# Altium schematic sheet Port.SchDoc
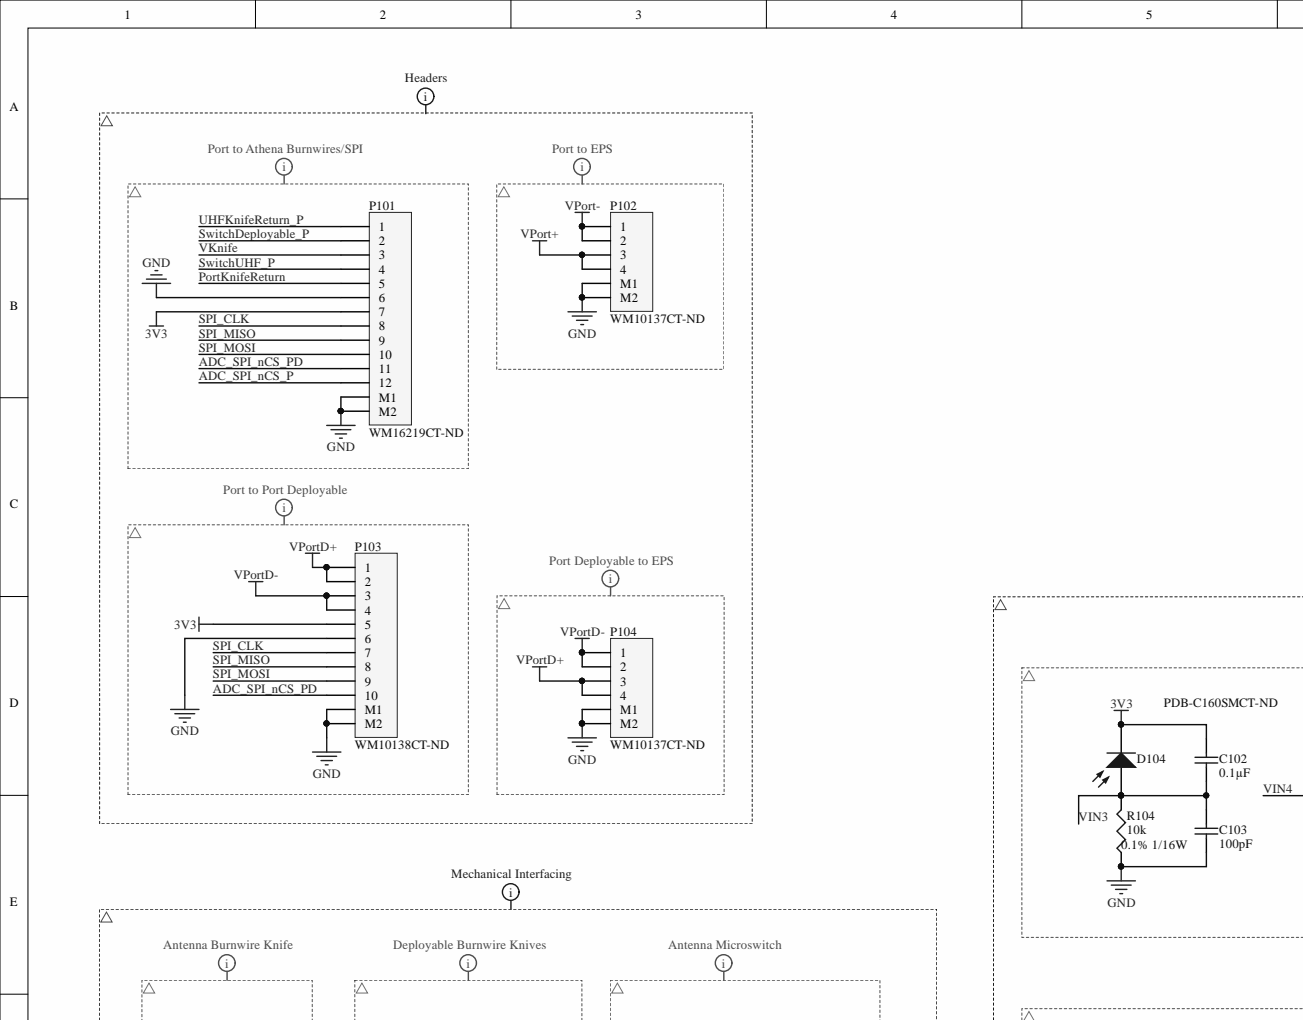
[diagram p0: Port.SchDoc, top left]
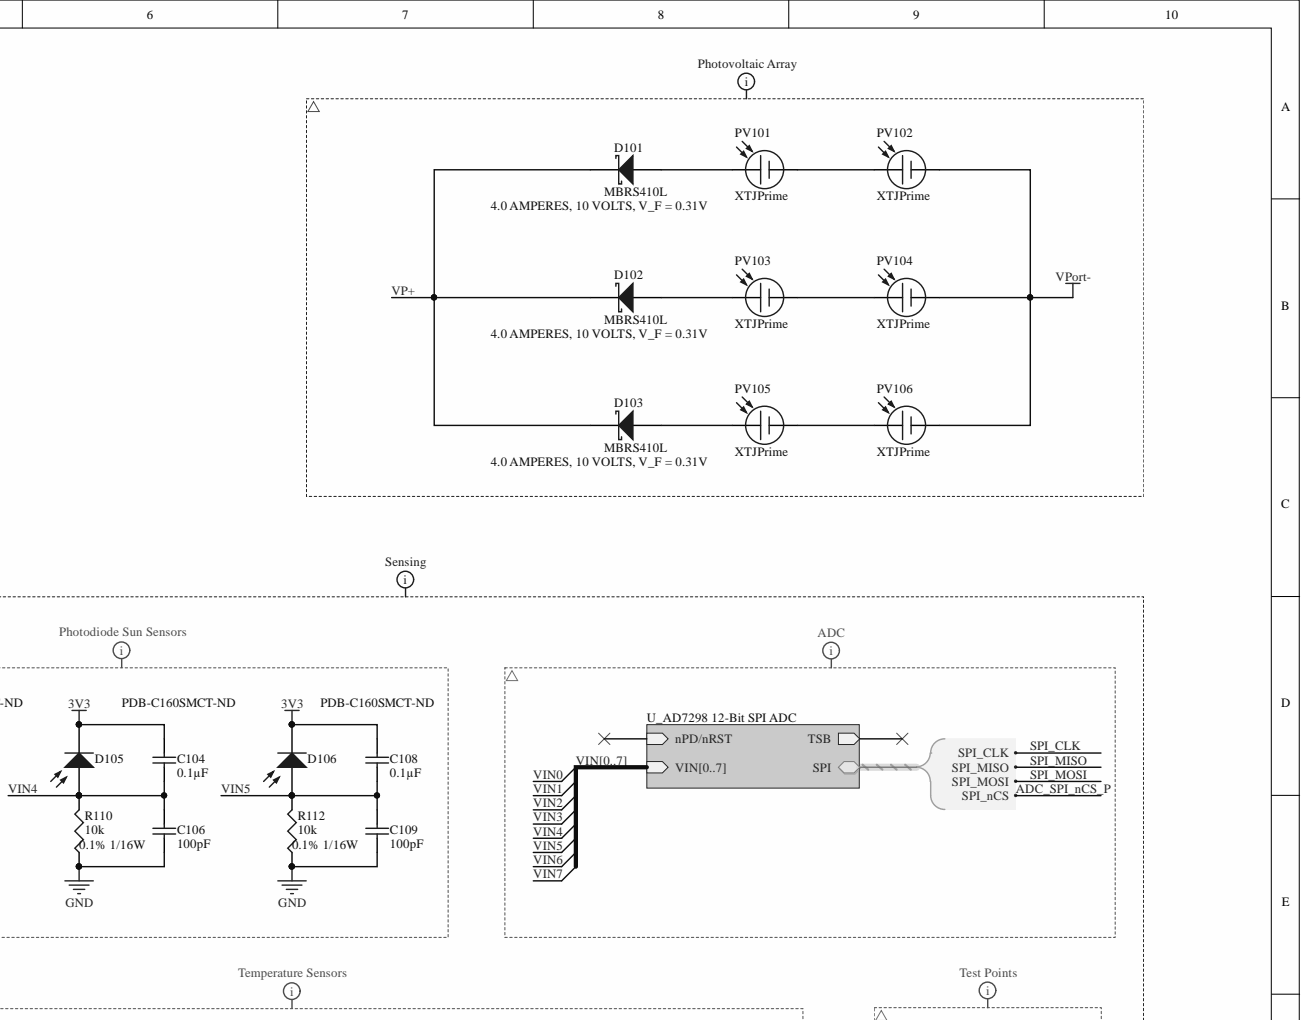
[diagram p1: Port.SchDoc, top right]
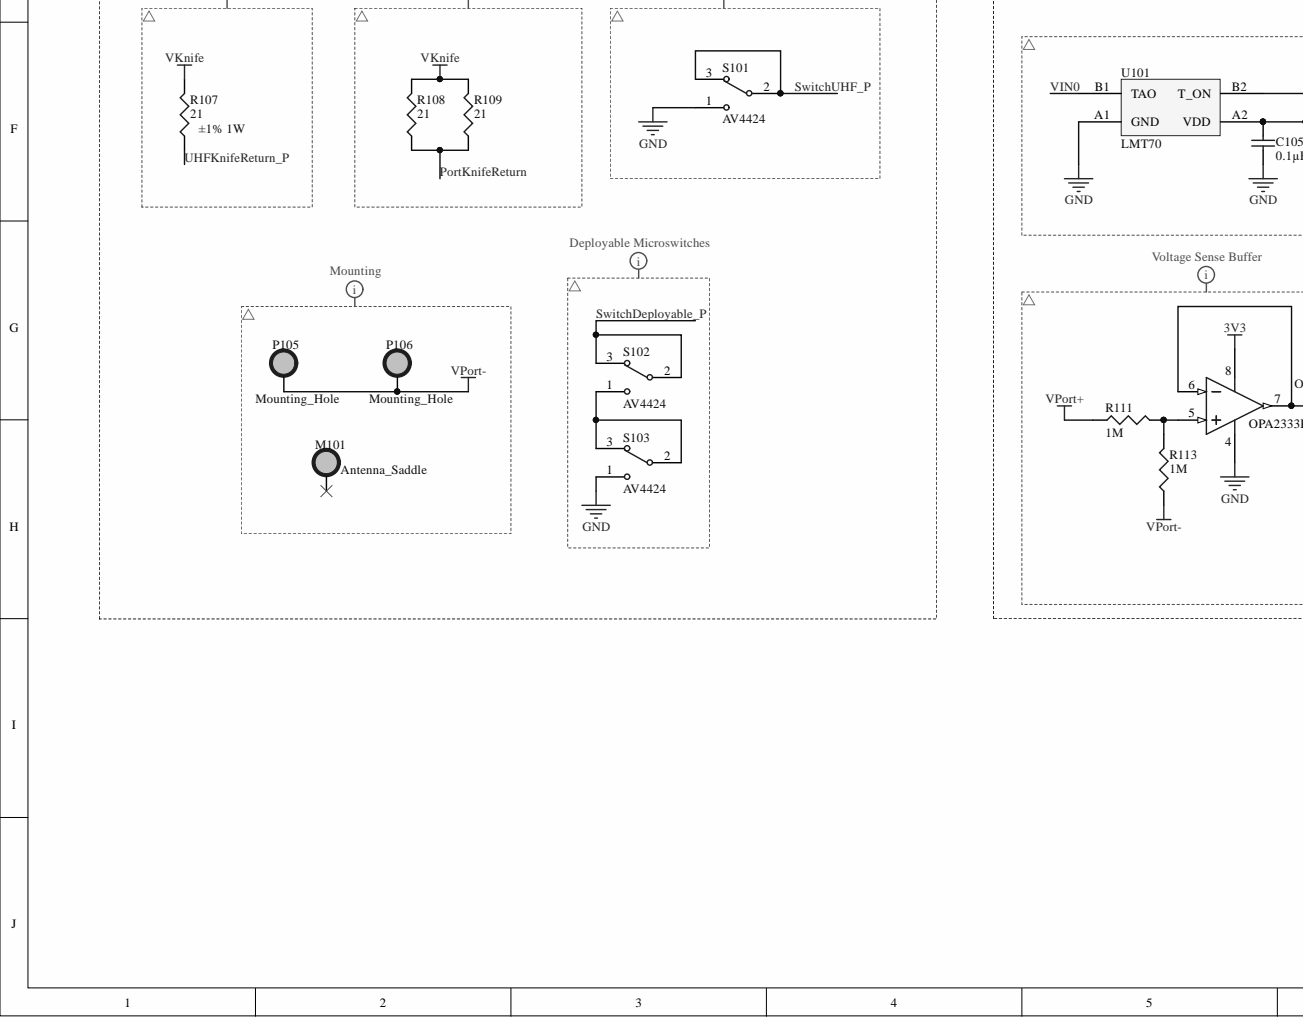
[diagram p2: Port.SchDoc, bottom left]
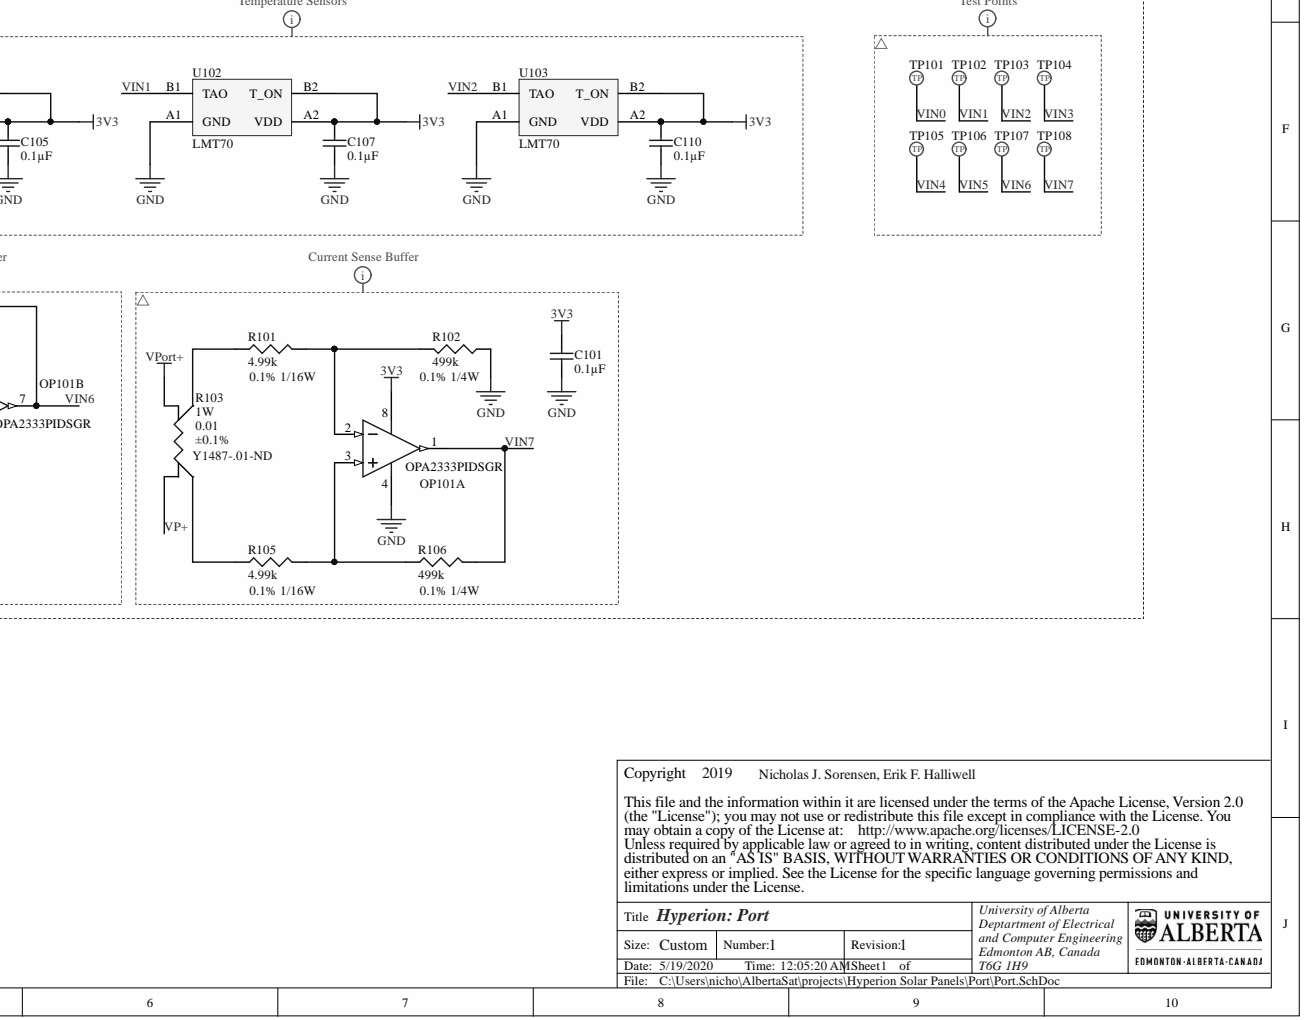
[diagram p3: Port.SchDoc, bottom right]

## Parts
C101  value 0.1µF  [TDK-CGA2B]  (hidden comment: Cap)
C102  value 0.1µF  [TDK-CGA2B]  (hidden comment: Cap)
C103  value 100pF  [TDK-CGA2B]  (hidden comment: Cap)
C104  value 0.1µF  [TDK-CGA2B]  (hidden comment: Cap)
C105  value 0.1µF  [TDK-CGA2B]  (hidden comment: Cap)
C106  value 100pF  [TDK-CGA2B]  (hidden comment: Cap)
C107  value 0.1µF  [TDK-CGA2B]  (hidden comment: Cap)
C108  value 0.1µF  [TDK-CGA2B]  (hidden comment: Cap)
C109  value 100pF  [TDK-CGA2B]  (hidden comment: Cap)
C110  value 0.1µF  [TDK-CGA2B]  (hidden comment: Cap)
D101  MBRS410L  [ONS-875]
D102  MBRS410L  [ONS-875]
D103  MBRS410L  [ONS-875]
D104  PDB-C160SMCT-ND  [PDB-C160SM]
D105  PDB-C160SMCT-ND  [PDB-C160SM]
D106  PDB-C160SMCT-ND  [PDB-C160SM]
M101  Antenna_Saddle  [ANTENNA_SADDLE]
OP101  OPA2333  Manufacturer Part Number: OPA2333PIDSGR  [TI-DSG0008A]  (parts A,B)
P101  WM16219CT-ND  [MOLX-5040501291]
P102  WM10137CT-ND  [MOLX-5040500491]
P103  WM10138CT-ND  [MOLX-5040501091]
P104  WM10137CT-ND  [MOLX-5040500491]
P105  Mounting_Hole  [DEPHINGE_MOUNTING_HOLE_BODY]
P106  Mounting_Hole  [DEPHINGE_MOUNTING_HOLE_BODY]
PV101  XTJPrime  [XTJ Prime]
PV102  XTJPrime  [XTJ Prime]
PV103  XTJPrime  [XTJ Prime]
PV104  XTJPrime  [XTJ Prime]
PV105  XTJPrime  [XTJ Prime]
PV106  XTJPrime  [XTJ Prime]
R101  0.1% 1/16W  value 4.99k  [PANA-ERA2A]
R102  0.1% 1/4W  value 499k  [PANA-ERA8A]
R103  Y1487-.01-ND  value 0.01  Power - Rated: 1W  Tolerance: ±0.1%  [VSH-2512R4C]
R104  0.1% 1/16W  value 10k  [PANA-ERA2A]
R105  0.1% 1/16W  value 4.99k  [PANA-ERA2A]
R106  0.1% 1/4W  value 499k  [PANA-ERA8A]
R107  ±1% 1W  value 21  Power - Rated: 1W  Tolerance: ±1%  [VSH-CPF1]
R108  value 21  [VSH-CPF1]  (hidden comment: Res3)
R109  value 21  [VSH-CPF1]  (hidden comment: Res3)
R110  0.1% 1/16W  value 10k  [PANA-ERA2A]
R111  value 1M  [PANA-ERA6A]  (hidden comment: Res3)
R112  0.1% 1/16W  value 10k  [PANA-ERA2A]
R113  value 1M  [PANA-ERA6A]  (hidden comment: Res3)
S101  AV4424  [PANA-AV4424]
S102  AV4424  [PANA-AV4424]
S103  AV4424  [PANA-AV4424]
TP101  5017  [KS-5017]  (value not drawn)
TP102  5017  [KS-5017]  (value not drawn)
TP103  5017  [KS-5017]  (value not drawn)
TP104  5017  [KS-5017]  (value not drawn)
TP105  5017  [KS-5017]  (value not drawn)
TP106  5017  [KS-5017]  (value not drawn)
TP107  5017  [KS-5017]  (value not drawn)
TP108  5017  [KS-5017]  (value not drawn)
U101  LMT70  [TI-YFQ0004]
U102  LMT70  [TI-YFQ0004]
U103  LMT70  [TI-YFQ0004]

## Nets
3V3: C101.2 C102.2 C104.2 C105.2 C107.2 C108.2 C110.2 D104.2 D105.2 D106.2 OP101.8 P101.7 P103.5 U101.A2(VDD) U101.B2(T_ON) U102.A2(VDD) U102.B2(T_ON) U103.A2(VDD) U103.B2(T_ON)
GND: C101.1 C103.1 C105.1 C106.1 C107.1 C109.1 C110.1 OP101.4 P101.6 P101.M1 P101.M2 P102.M1 P102.M2 P103.6 P103.M1 P103.M2 P104.M1 P104.M2 R102.1 R104.1 R110.1 R112.1 S101.1 S103.1 U101.A1(GND) U102.A1(GND) U103.A1(GND)
VPort+: P102.3 P102.4 R103.2 R111.1
VPort-: P102.1 P102.2 P105.1 P106.1 PV102.2 PV104.2 PV106.2 R113.1
VPortD+: P103.1 P103.2 P104.3 P104.4
VPortD-: P103.3 P103.4 P104.1 P104.2
ADC_SPI_nCS_P: P101.12
ADC_SPI_nCS_PD: P101.11 P103.10
PortKnifeReturn: P101.5 R108.1 R109.1
SPI_CLK: P101.8 P103.7
SPI_MISO: P101.9 P103.8
SPI_MOSI: P101.10 P103.9
SwitchDeployable_P: P101.2 S102.2 S102.3
SwitchUHF_P: P101.4 S101.2 S101.3
UHFKnifeReturn_P: P101.1 R107.1
VIN0: TP101.1 U101.B1(TAO)  [also labelled VIN]
VIN1: TP102.1 U102.B1(TAO)  [also labelled VIN]
VIN2: TP103.1 U103.B1(TAO)  [also labelled VIN]
VIN3: C102.1 C103.2 D104.1 R104.2 TP104.1  [also labelled VIN]
VIN4: C104.1 C106.2 D105.1 R110.2 TP105.1  [also labelled VIN]
VIN5: C108.1 C109.2 D106.1 R112.2 TP106.1  [also labelled VIN]
VIN6: OP101.6 OP101.7 TP107.1  [also labelled VIN]
VIN7: OP101.1 R106.2 TP108.1  [also labelled VIN]
VKnife: P101.3 R107.2 R108.2 R109.2
VP+: D101.2 D102.2 D103.2 R103.1
NetD101_1: D101.1 PV101.1
NetD102_1: D102.1 PV103.1
NetD103_1: D103.1 PV105.1
NetM101_1: M101.1
NetOP101_2: OP101.2 R101.2 R102.2
NetOP101_3: OP101.3 R105.2 R106.1
NetOP101_5: OP101.5 R111.2 R113.2
NetPV101_2: PV101.2 PV102.1
NetPV103_2: PV103.2 PV104.1
NetPV105_2: PV105.2 PV106.1
NetR101_1: R101.1 R103.3
NetR103_4: R103.4 R105.1
NetS102_1: S102.1 S103.2 S103.3
N00005:
N00006:
N00007:
N00008:
N00009:
N00010:
N00011:
N00012:
N00013:
N00014:
N00015:
N00016:
N00017:
N00018:
N00019:
N00020:
N00021:
N00022:
N00023:
nPD/nRST:
TSB:

## Library data of the parts
C101 = PC-TDK-02255: CAP CER 0.1UF 35V X7R 0402; Manufacturer Part Number: CGA2B3X7R1V104K050BB; Database Table Name: TDK Corporation; Index: 2255; Tolerance: ±10%; Applications: Automotive; Component Kind: Standard; Designator: C?; LatestRevisionDate: 2016-03-16; LatestRevisionNote: ...; Library Name: Database.DbLib; PackageDescription: Radial Cap, Thru-Hole; 2 Leads; 0.3 in Pin Spacing; PackageReference: 0402 (1005 Metric); Part Number: PC-TDK-02255; Published: 2016-03-16; Signal Integrity: Cap; Simulation: CAP; Supplier 1: Digi-key; Supplier Link: http://www.digikey.ca/product-search/en?keywords=445-6901-1-ND; Supplier Part Number 1: 445-6901-1-ND; Temperature Coefficient: X7R; Voltage - Rated: 35V; Publisher: AlbertaSat
C102 C104 C105 C107 C108 C110 = PC-TDK-02259: CAP CER 0.1UF 50V X7R 0402; Manufacturer Part Number: CGA2B3X7R1H104K050BB; Database Table Name: TDK Corporation; Index: 2259; Tolerance: ±10%; Applications: Automotive; Component Kind: Standard; LatestRevisionDate: 2016-03-16; LatestRevisionNote: ...; Library Name: Database.DbLib; PackageDescription: Radial Cap, Thru-Hole; 2 Leads; 0.3 in Pin Spacing; PackageReference: 0402 (1005 Metric); Part Number: PC-TDK-02259; Published: 2016-03-16; Signal Integrity: Cap; Simulation: CAP; Supplier 1: Digi-key; Supplier Link: http://www.digikey.ca/product-search/en?keywords=445-6899-1-ND; Supplier Part Number 1: 445-6899-1-ND; Temperature Coefficient: X7R; Voltage - Rated: 50V; Publisher: AlbertaSat
C103 C106 C109 = PC-TDK-01227: CAP CER 100PF 50V C0G 0402; Manufacturer Part Number: CGA2B2C0G1H101J050BA; Database Table Name: TDK Corporation; Index: 1227; Temperature Coefficient: NP0; Tolerance: ±5%; Applications: Automotive; Component Kind: Standard; LatestRevisionDate: 2016-03-16; LatestRevisionNote: ...; Library Name: Database.DbLib; PackageDescription: Radial Cap, Thru-Hole; 2 Leads; 0.3 in Pin Spacing; PackageReference: 0402 (1005 Metric); Part Number: PC-TDK-01227; Published: 2016-03-16; Signal Integrity: Cap; Simulation: CAP; Supplier 1: Digi-key; Supplier Link: http://www.digikey.ca/product-search/en?keywords=445-5596-1-ND; Supplier Part Number 1: 445-5596-1-ND; Voltage - Rated: 50V; Publisher: AlbertaSat
D101-D103 = PXX-ONS-00003: SCHOTTKY BARRIER  RECTIFIERS  4.0 AMPERES, 10 VOLTS, V_F = 0.31V; Database Table Name: ON Semiconductor; Index: 3; Code_JEDEC: DO-214-AA; Component Kind: Standard; Designator: U?; LatestRevisionDate: 1/18/2020; LatestRevisionNote: ...; Library Name: Database.DbLib; Manufacturer Part Number: MBRS410L; PackageDescription: DO-214-AA/SMB; 2 C-Bend Leads; Body 5.3 x 3.6 mm, inc. leads (LxW); PackageReference: SMB; Part Number: PXX-ONS-00003; Published: 1/18/2020; Signal Integrity: D Schottky; Simulation: SKYDIODE; Supplier 1: Digi-key; Supplier Link: #https://www.digikey.com/product-detail/en/on-semiconductor/MBRS410LT3G/MBRS410LT3GOSCT-ND/1967073#; Supplier Part Number 1: MBRS410LT3-D; Publisher: AlbertaSat
D104-D106 = PXX-ADP-00000: SENSOR PHOTODIODE 850NM 2SMD GW; Database Table Name: Advanced Photonics; Index: 1; Component Kind: Standard; Designator: D?; LatestRevisionDate: 3/28/2020; LatestRevisionNote: ...; Library Name: Database.DbLib; Manufacturer Part Number: Advanced Photonics; PackageReference: PIN2; Part Number: PXX-ADP-00000; Published: 3/28/2020; Supplier 1: Digi-key; Supplier Part Number 1: PDB-C160SMCT-ND; Publisher: AlbertaSat
M101 = PXX-ABS-00001: Manufacturer Part Number: Custom; Database Table Name: AlbertaSat_Cust; Index: 1; Component Kind: Standard; Designator: M?; LatestRevisionNote: ...; Library Name: Database.DbLib; Part Number: PXX-ABS-00001; Supplier 1: Digi-key; Publisher: AlbertaSat
OP101 = 73: CMOS Amplifier 2 Circuit Rail-to-Rail 8-WSON (2x2); Database Table Name: Texas Instruments; Voltage - Supply: 1.8V ~ 5.5V, ±0.9V ~ 2.75V; Component Kind: Standard; Designator: U?; Library Name: Database.DbLib; Operating Temperature: -55°C ~ 150°C; PackageReference: 8-WFDFN Exposed Pad; Part Number: 73; Supplier 1: Digi-Key; Supplier 2: Mouser; Supplier Link: #https://www.digikey.ca/product-detail/en/texas-instruments/OPA2333PIDSGR/296-51501-1-ND/9745865#; Supplier Part Number 1: 296-51501-1-ND; Supplier Part Number 2: 595-OPA2333PIDSGR
P101 = 71: CONN HEADER SMD R/A 12POS 1.5MM; Manufacturer Part Number: 5040501291; Database Table Name: Molex; Component Kind: Standard; Designator: P?; LatestRevisionDate: 17-Jul-2002; LatestRevisionNote: Re-released for DXP Platform.; Library Name: Database.DbLib; Part Number: 71; Signal Integrity: Connector; Supplier 1: Digi-Key; Supplier Link: #https://www.digikey.ca/product-detail/en/molex/5040501291/WM16219CT-ND/6702294#; Supplier Part Number 1: WM16219CT-ND; Publisher: Altium Limited
P102 P104 = 63: CONN HEADER SMD R/A 4POS 1.5MM; Manufacturer Part Number: 5040500491; Database Table Name: Molex; Component Kind: Standard; Designator: P?; LatestRevisionDate: 17-Jul-2002; LatestRevisionNote: Re-released for DXP Platform.; Library Name: Database.DbLib; Part Number: 63; Signal Integrity: Connector; Supplier 1: Digi-Key; Supplier Link: #https://www.digikey.ca/product-detail/en/molex/5040500491/WM10137CT-ND/4357653#; Supplier Part Number 1: WM10137CT-ND; Publisher: Altium Limited
P103 = 69: CONN HEADER SMD R/A 10POS 1.5MM; Manufacturer Part Number: 5040501091; Database Table Name: Molex; Component Kind: Standard; Designator: P?; LatestRevisionDate: 17-Jul-2002; LatestRevisionNote: Re-released for DXP Platform.; Library Name: Database.DbLib; Part Number: 69; Signal Integrity: Connector; Supplier 1: Digi-Key; Supplier Link: #https://www.digikey.ca/product-detail/en/molex/5040501091/WM10138CT-ND/4357655#; Supplier Part Number 1: WM10138CT-ND; Publisher: Altium Limited
P105 P106 = PXX-ABS-00004: Manufacturer Part Number: Custom; Database Table Name: AlbertaSat_Cust; Index: 4; Component Kind: Standard; LatestRevisionNote: ...; Library Name: Database.DbLib; Part Number: PXX-ABS-00004; Supplier 1: Digi-key; Publisher: AlbertaSat
PV101-PV106 = PBT-SPEC-00002: Database Table Name: Spectrolab; Index: 2; Component Kind: Standard; LatestRevisionNote: ...; Library Name: Database.DbLib; Manufacturer Part Number: Spectrolab; PackageReference: 3-Connector Solar Cell; Part Number: PBT-SPEC-00002; Supplier 1: Spectrolab; Supplier Part Number 1: UTJ CIC 26.26cm^2; Publisher: AlbertaSat
R101 R105 = 16697: RES SMD 4.99KOHM 0.1% 1/16W 0402; Manufacturer Part Number: ERA-2ARB4991X; Database Table Name: Panasonic; Power: 0.063W, 1/16W; Temperature Coefficient: ±10ppm/°C; Component Kind: Standard; Designator: R?; LatestRevisionDate: 29-May-2009; LatestRevisionNote: IPC-7351 Footprint Added.; Library Name: Database.DbLib; PackageDescription: Chip Resistor, 2-Leads, Body 1.00x0.50mm, IPC Low Density; PackageReference: 0402 (1005 Metric); Part Number: 16697; Published: 8-Jun-2000; Signal Integrity: Res; Simulation: RESISTOR; Supplier 1: Digi-Key; Supplier Link: http://www.digikey.ca/product-search/en?keywords=P18079CT-ND; Supplier Part Number 1: P18079CT-ND; Tolerance: ±0.1%; Publisher: Altium Limited
R102 R106 = 19434: RES SMD 499K OHM 0.1% 1/4W 1206; Manufacturer Part Number: ERA-8AEB4993V; Database Table Name: Panasonic; Power: 0.25W, 1/4W; Temperature Coefficient: ±25ppm/°C; Component Kind: Standard; Designator: R?; LatestRevisionDate: 29-May-2009; LatestRevisionNote: IPC-7351 Footprint Added.; Library Name: Database.DbLib; PackageDescription: Chip Resistor, 2-Leads, Body 3.20x1.60mm, IPC Low Density; PackageReference: 1206 (3216 Metric); Part Number: 19434; Published: 8-Jun-2000; Signal Integrity: Res; Simulation: RESISTOR; Supplier 1: Digi-Key; Supplier Link: http://www.digikey.ca/product-search/en?keywords=P499KBCCT-ND; Supplier Part Number 1: P499KBCCT-ND; Tolerance: ±0.1%; Publisher: Altium Limited
R103 = PR-VSH-00237: RES SMD 0.01 OHM 0.1% 1W 2512; Manufacturer Part Number: Y14870R01000B9W; Database Table Name: Vishay; Index: 237; Temperature Coefficient: ±15ppm/°C; Applications: Automotive; Component Kind: Standard; Designator: R?; LatestRevisionDate: 2017-07-12; LatestRevisionNote: ...; Library Name: Database.DbLib; PackageReference: VSH-2512R4C; Part Number: PR-VSH-00237; Published: 2017-07-12; Supplier 1: Digi-key; Supplier Link: http://www.digikey.ca/product-search/en?keywords=Y1487-.01-ND; Supplier Part Number 1: Y1487-.01-ND; Publisher: AlbertaSat
R104 R110 R112 = 17193: RES SMD 10K OHM 0.1% 1/16W 0402; Manufacturer Part Number: ERA-2ARB103X; Database Table Name: Panasonic; Power: 0.063W, 1/16W; Temperature Coefficient: ±10ppm/°C; Component Kind: Standard; LatestRevisionDate: 29-May-2009; LatestRevisionNote: IPC-7351 Footprint Added.; Library Name: Database.DbLib; PackageDescription: Chip Resistor, 2-Leads, Body 1.00x0.50mm, IPC Low Density; PackageReference: 0402 (1005 Metric); Part Number: 17193; Published: 8-Jun-2000; Signal Integrity: Res; Simulation: RESISTOR; Supplier 1: Digi-Key; Supplier Link: http://www.digikey.ca/product-search/en?keywords=P10KCPCT-ND; Supplier Part Number 1: P10KCPCT-ND; Tolerance: ±0.1%; Publisher: Altium Limited
R107-R109 = PR-VSH-00317: 21 Ohms ±1% 1W Through Hole Resistor Axial Flame Proof, Moisture Resistant, Safety Metal Film; Manufacturer Part Number: CPF121R000FKEE6; Database Table Name: Vishay; Index: 317; Temperature Coefficient: ±100ppm/°C; Component Kind: Standard; Designator: R?; LatestRevisionDate: 2/27/2020; LatestRevisionNote: ...; Library Name: Database.DbLib; PackageDescription: Chip Resistor; PackageReference: VSH-CPF1; Part Number: PR-VSH-00317; Published: 2/27/2020; Signal Integrity: Res; Simulation: RESISTOR; Supplier 1: Digi-key; Supplier Link: #https://www.digikey.ca/product-detail/en/vishay-dale/CPF121R000FKEE6/CPF21.0CCT-ND/2713126#; Supplier Part Number 1: CPF21.0CCT-ND; Publisher: AlbertaSat
  R108 R109: Power - Rated: 1W; Tolerance: ±1%
R111 R113 = 19706: RES SMD 1M OHM 0.1% 1/8W 0805; Manufacturer Part Number: ERA-6AEB105V; Database Table Name: Panasonic; Power: 0.125W, 1/8W; Temperature Coefficient: ±25ppm/°C; Component Kind: Standard; Designator: R?; LatestRevisionDate: 29-May-2009; LatestRevisionNote: IPC-7351 Footprint Added.; Library Name: Database.DbLib; PackageDescription: Chip Resistor, 2-Leads, Body 2.00x1.25mm, IPC Low Density; PackageReference: 0805 (2012 Metric); Part Number: 19706; Published: 8-Jun-2000; Signal Integrity: Res; Simulation: RESISTOR; Supplier 1: Digi-Key; Supplier Link: http://www.digikey.ca/product-search/en?keywords=P1MDACT-ND; Supplier Part Number 1: P1MDACT-ND; Tolerance: ±0.1%; Publisher: Altium Limited
S101-S103 = 20035: SWITCH SNAP ACT SPDT 500MA 30V; Database Table Name: Panasonic; Component Kind: Standard; Library Name: Database.DbLib; Manufacturer Part Number: AV4424; Part Number: 20035; Supplier 1: Digi-Key; Supplier Link: #https://www.digikey.com/product-detail/en/panasonic-electric-works/AV4424/255-3743-ND/646766#; Supplier Part Number 1: 255-3743-ND
TP101-TP108 = PTP-KS-00001: PC TEST POINT MINI SMD; Database Table Name: Keystone; Index: 1; Component Kind: Standard; LatestRevisionDate: 3/15/2016; LatestRevisionNote: ...; Library Name: Database.DbLib; Manufacturer Part Number: 5017; PackageDescription: Testpoint Lug Mini SMD 27X10X152; PackageReference: KS-5017; Part Number: PTP-KS-00001; Published: 3/15/2016; Supplier 1: Digi-key; Supplier 2: Newark; Supplier 3: Mouser; Supplier Link: http://www.digikey.ca/product-detail/en/keystone-electronics/5017/36-5017TR-ND/2217304; Supplier Part Number 1: 36-5017CT-ND; Publisher: AlbertaSat
U101-U103 = 70: Temperature Sensor Analog, Local -55°C ~ 150°C 5.194mV/°C 4-DSBGA (0.81x0.81); Manufacturer Part Number: LMT70YFQR; Database Table Name: Texas Instruments; Component Kind: Standard; Library Name: Database.DbLib; Operating Temperature: -55°C ~ 150°C; PackageReference: BGA, 4-Leads, Body 0.88x0.88mmx0.68mm, Pitch 0.40mm; Part Number: 70; Supplier 1: Digi-Key; Supplier Link: #https://www.digikey.ca/product-detail/en/texas-instruments/LMT70YFQR/296-47337-1-ND/7688347#; Supplier Part Number 1: 296-47337-1-ND
